# Altium schematic sheet 5-Latching Delay.SchDoc("5-Latching Delay")
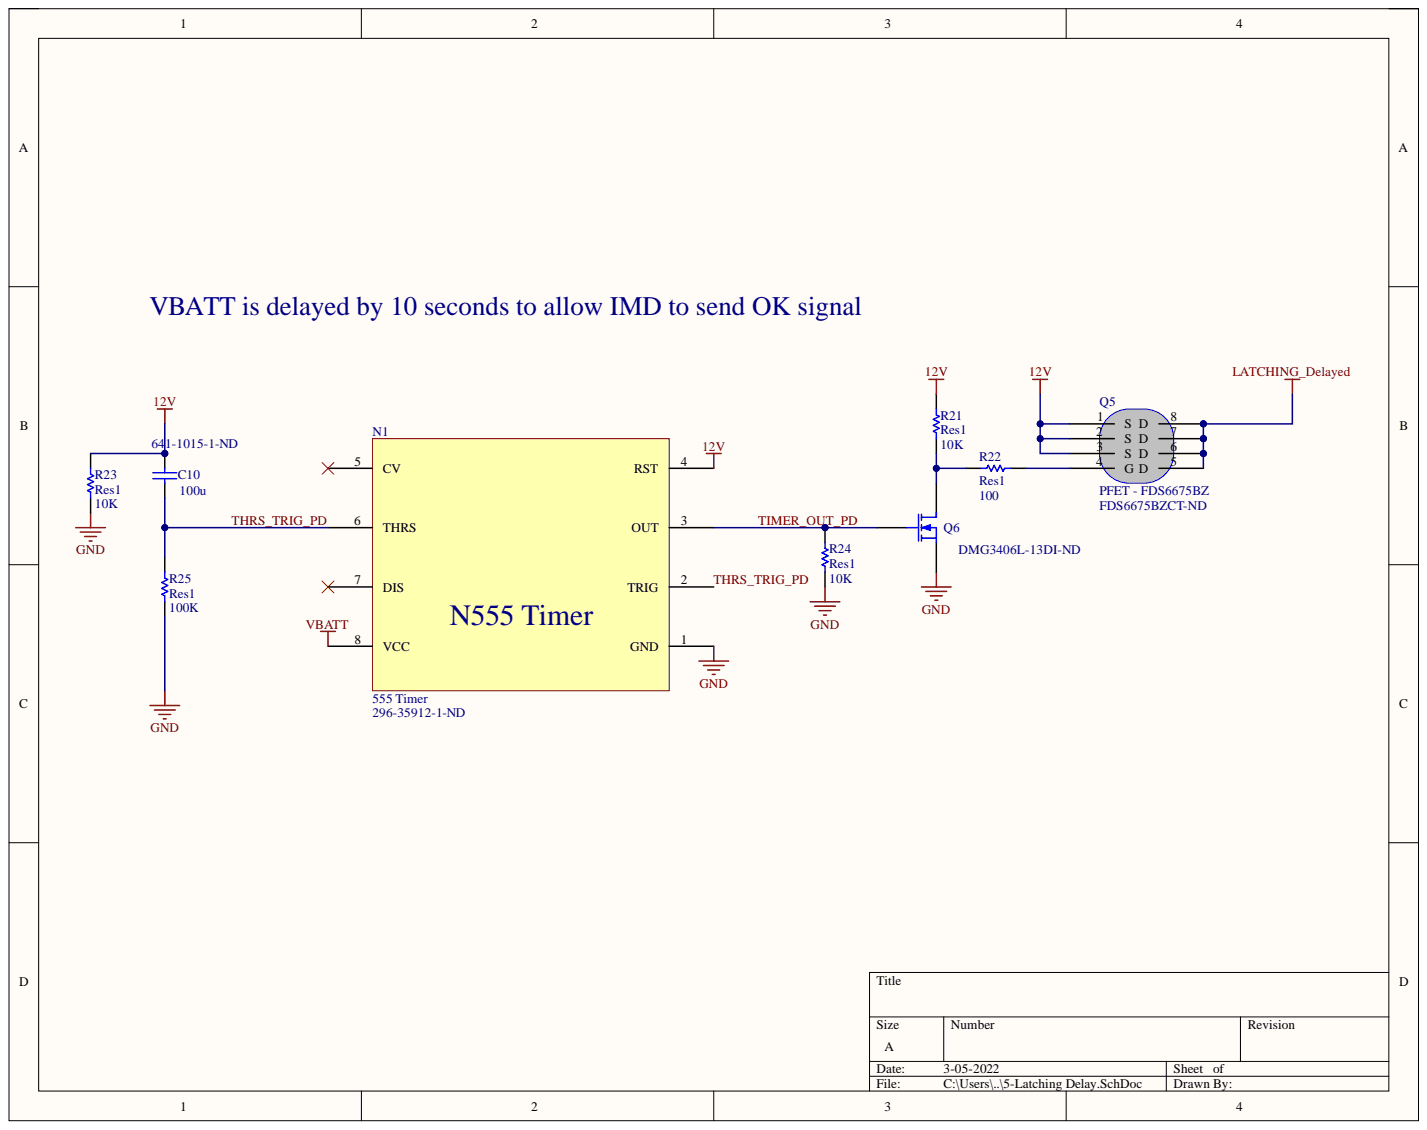
[diagram p0: 5-Latching Delay.SchDoc]

## Parts
C10  100u  Supplier Part Number 1: 641-1015-1-ND  [C1210]
N1  555 Timer  Supplier Part Number 1: 296-35912-1-ND  [SO8_M]
Q5  PFET - FDS6675BZ  Manufacturer Part Number: FDS6675BZ  Supplier Part Number 1: FDS6675BZCT-ND  [SOIC127P600X175-8N]
Q6  Supplier Part Number 1: DMG3406L-13DI-ND  [SOT-23_N]
R21  Res1  value 10K  [1608[0603]]
R22  Res1  value 100  [1608[0603]]
R23  Res1  value 10K  [1608[0603]]
R24  Res1  value 10K  [1608[0603]]
R25  Res1  value 100K  [1608[0603]]

## Nets
12V: C10.1 N1.4(RST) Q5.1(S) Q5.2(S) Q5.3(S) R21.2 R23.2
GND: N1.1(GND) Q6.2 R23.1 R24.1 R25.1
LATCHING_Delayed: Q5.5(D) Q5.6(D) Q5.7(D) Q5.8(D)
VBATT: N1.8(VCC)
THRS_TRIG_PD: C10.2 N1.2(TRIG) N1.6(THRS) R25.2
TIMER_OUT_PD: N1.3(OUT) Q6.1 R24.2
NetQ5_4: Q5.4(G) R22.2
NetQ6_3: Q6.3 R21.1 R22.1

Not connected: N1 5(CV) 7(DIS)

## Library data of the parts
C10 = Cap: CAP CER 100UF 6.3V X5R 1206; Manufacturer: Murata Electronics North America; Manufacturer Part Number: GRM31CR60J107ME39K; Capacitance: 100µF; Tolerance: ±20%; Applications: General Purpose; Category: Capacitors; Component Kind: Standard; ComponentLink1Description: http://www.murata.com/~/media/webrenewal/support/library/catalog/products/capacitor/mlcc/c02e.ashx; ComponentLink1URL: http://www.murata.com/~/media/webrenewal/support/library/catalog/products/capacitor/mlcc/c02e.ashx; Features: -; Height - Seated (Max): -; Lead Spacing: -; Lead Style: -; Library Name: Miscellaneous Devices.IntLib; Mounting Type: Surface Mount, MLCC; Operating Temperature: -55°C ~ 85°C; Package / Case: 1206 (3216 Metric); Packaging: Cut Tape (CT); Part Status: Active; Ratings: -; RoHS: RoHS Compliant; Signal Integrity: Cap; Simulation: CAP; Size / Dimension: 0.126" L x 0.063" W (3.20mm x 1.60mm); Supplier 1: Digi-Key; Temperature Coefficient: X5R; Thickness (Max): 0.071" (1.80mm); Voltage - Rated: 6.3V
N1 = 555 Timer: IC OSC SGL TIMER 100KHZ 8-SOIC; Manufacturer: Texas Instruments; Manufacturer Part Number: NE555DRG4; Current - Supply: 10mA; Voltage - Supply: 4.5 V ~ 16 V; Category: Integrated Circuits (ICs); Component Kind: Standard; ComponentLink1Description: http://www.ti.com/lit/ds/symlink/ne555.pdf; ComponentLink1URL: http://www.ti.com/lit/ds/symlink/ne555.pdf; Count: -; Frequency: 100kHz; Mounting Type: Surface Mount; Operating Temperature: 0°C ~ 70°C; Package / Case: 8-SOIC (0.154", 3.90mm Width); Packaging: Cut Tape (CT); Part Status: Active; Pricing 1: 1=0.71, 10=0.532, 100=0.3314, 500=0.22672, 1000=0.17441 (CAD); RoHS: RoHS Compliant; Stock 1: 6208; Supplier 1: Digi-Key; Supplier Device Package: 8-SOIC; Type: 555 Type, Timer/Oscillator (Single); Library Name: Latching  2017 - IMD.SCHLIB
Q5 = MOSFET: MOSFET P-CH 8-SOIC; Manufacturer: Fairchild/ON Semiconductor; Current - Continuous Drain (Id) @ 25°C: 11A (Ta); Drain to Source Voltage (Vdss): 30V; Drive Voltage (Max Rds On,  Min Rds On): 4.5V, 10V; FET Type: P-Channel; Gate Charge (Qg) (Max) @ Vgs: 62nC @ 10V; Input Capacitance (Ciss) (Max) @ Vds: 2470pF @ 15V; Power Dissipation (Max): 2.5W (Ta); Rds On (Max) @ Id, Vgs: 13 mOhm @ 11A, 10V; Vgs (Max): ±25V; Vgs(th) (Max) @ Id: 3V @ 250µA; Category: Discrete Semiconductor Products; Component Kind: Standard; ComponentLink1Description: https://www.fairchildsemi.com/datasheets/FD/FDS6675BZ.pdf; ComponentLink1URL: https://www.fairchildsemi.com/datasheets/FD/FDS6675BZ.pdf; FET Feature: -; Mounting Type: Surface Mount; Operating Temperature: -55°C ~ 150°C (TJ); Package / Case: 8-SOIC (0.154", 3.90mm Width); Packaging: Cut Tape (CT); Part Status: Active; Pricing 1: 1=1.29, 10=1.156, 100=0.9013, 500=0.74452, 1000=0.58778 (CAD); RoHS: RoHS Compliant; Stock 1: 7940; Supplier 1: Digi-Key; Supplier Device Package: 8-SO; Technology: MOSFET (Metal Oxide); Library Name: Latching_Reset_Library.SchLib
Q6 = MOSFET-N: N-Channel MOSFET; Manufacturer: Infineon Technologies; Manufacturer Part Number: IPD075N03L G; Current - Continuous Drain (Id) @ 25°C: 50A (Tc); Drain to Source Voltage (Vdss): 30V; FET Feature: Logic Level Gate; FET Type: MOSFET N-Channel, Metal Oxide; Gate Charge (Qg) @ Vgs: 18nC @ 10V; Input Capacitance (Ciss) @ Vds: 1900pF @ 15V; Power - Max: 47W; Rds On (Max) @ Id, Vgs: 7.5 mOhm @ 30A, 10V; Vgs(th) (Max) @ Id: 2.2V @ 250µA; Category: Discrete Semiconductor Products; Component Kind: Standard; ComponentLink1Description: http://www.infineon.com/dgdl/Infineon-IPD075N03LG-DS-v02_01-en.pdf?folderId=5546d4694909da4801490a07012f053b&fileId=db3a30431936bc4b011951d824f83aeb; ComponentLink1URL: http://www.infineon.com/dgdl/Infineon-IPD075N03LG-DS-v02_01-en.pdf?folderId=5546d4694909da4801490a07012f053b&fileId=db3a30431936bc4b011951d824f83aeb; ComponentLink2Description: http://www.semicon.toshiba.co.jp/info/docget.jsp?type=datasheet&lang=en&pid=SSM3K329R; ComponentLink2URL: http://www.semicon.toshiba.co.jp/info/docget.jsp?type=datasheet&lang=en&pid=SSM3K329R; LatestRevisionDate: 17-Jul-2002; LatestRevisionNote: Re-released for DXP Platform.; Library Name: Miscellaneous Devices.IntLib; Mounting Type: Surface Mount; Package / Case: TO-252-3, DPak (2 Leads + Tab), SC-63; PackageDescription: TO, Flat Index; 3 In-Line, Axial Leads; Body Dia. 4.6mm; Lead Dia. 0.5 mm (max); PackageReference: E3; PackageVersion: 1995/1996; Packaging: Cut Tape (CT); Published: 8-Jun-2000; RoHS: RoHS Compliant; Simulation: NMOS; Supplier 1: Digi-Key; Supplier Device Package: PG-TO252-3; Publisher: Altium Limited
R21 R23 R24 = Res1: RES SMD 10K OHM 5% 1/4W 0603; Manufacturer: Rohm Semiconductor; Manufacturer Part Number: ESR03EZPJ103; Power (Watts): 0.25W, 1/4W; Temperature Coefficient: ±200ppm/°C; Category: Resistors; Component Kind: Standard; ComponentLink1Description: http://rohmfs.rohm.com/en/products/databook/datasheet/passive/resistor/chip_resistor/esr.pdf; ComponentLink1URL: http://rohmfs.rohm.com/en/products/databook/datasheet/passive/resistor/chip_resistor/esr.pdf; Composition: Thick Film; Failure Rate: -; Features: Automotive AEC-Q200, Pulse Withstanding; Height - Seated (Max): 0.022" (0.55mm); LatestRevisionDate: 17-Jul-2002; LatestRevisionNote: Re-released for DXP Platform.; Library Name: Miscellaneous Devices.IntLib; Operating Temperature: -55°C ~ 155°C; Package / Case: 0603 (1608 Metric); PackageDescription: Axial Device, Thru-Hole; 2 Leads; 0.3 in Pin Spacing; PackageReference: AXIAL-0.3; Packaging: Cut Tape (CT); Part Status: Active; Pricing 1: 1=0.15, 10=0.119, 25=0.0824, 50=0.0642, 100=0.0458, 250=0.03568, 500=0.02836, 1000=0.02012 (CAD); Published: 8-Jun-2000; Resistance (Ohms): 10k; RoHS: RoHS Compliant; Signal Integrity: Res; Simulation: RESISTOR; Size / Dimension: 0.063" L x 0.031" W (1.60mm x 0.80mm); Stock 1: 0; Supplier 1: Digi-Key; Supplier Device Package: 0603; Supplier Part Number 1: RHM10KDCT-ND; Tolerance: ±5%; Publisher: Altium Limited
R22 = Res1: RES SMD 100 OHM 5% 1/4W 0603; Manufacturer: Rohm Semiconductor; Manufacturer Part Number: ESR03EZPJ101; Power (Watts): 0.25W, 1/4W; Temperature Coefficient: ±200ppm/°C; Category: Resistors; Component Kind: Standard; ComponentLink1Description: http://rohmfs.rohm.com/en/products/databook/datasheet/passive/resistor/chip_resistor/esr.pdf; ComponentLink1URL: http://rohmfs.rohm.com/en/products/databook/datasheet/passive/resistor/chip_resistor/esr.pdf; Composition: Thick Film; Failure Rate: -; Features: Automotive AEC-Q200, Pulse Withstanding; Height - Seated (Max): 0.022" (0.55mm); LatestRevisionDate: 17-Jul-2002; LatestRevisionNote: Re-released for DXP Platform.; Library Name: Miscellaneous Devices.IntLib; Operating Temperature: -55°C ~ 155°C; Package / Case: 0603 (1608 Metric); PackageDescription: Axial Device, Thru-Hole; 2 Leads; 0.3 in Pin Spacing; PackageReference: AXIAL-0.3; Packaging: Cut Tape (CT); Part Status: Active; Pricing 1: 1=0.15, 10=0.119, 25=0.0824, 50=0.0642, 100=0.0458, 250=0.03568, 500=0.02836, 1000=0.02012 (CAD); Published: 8-Jun-2000; Resistance (Ohms): 100; RoHS: RoHS Compliant; Signal Integrity: Res; Simulation: RESISTOR; Size / Dimension: 0.063" L x 0.031" W (1.60mm x 0.80mm); Stock 1: 57430; Supplier 1: Digi-Key; Supplier Device Package: 0603; Supplier Part Number 1: RHM100DCT-ND; Tolerance: ±5%; Publisher: Altium Limited
R25 = Res1: RES SMD 33K OHM 5% 1/4W 0603; Manufacturer: Rohm Semiconductor; Manufacturer Part Number: ESR03EZPJ333; Power (Watts): 0.25W, 1/4W; Temperature Coefficient: ±200ppm/°C; Category: Resistors; Component Kind: Standard; ComponentLink1Description: http://rohmfs.rohm.com/en/products/databook/datasheet/passive/resistor/chip_resistor/esr.pdf; ComponentLink1URL: http://rohmfs.rohm.com/en/products/databook/datasheet/passive/resistor/chip_resistor/esr.pdf; Composition: Thick Film; Failure Rate: -; Features: Automotive AEC-Q200, Pulse Withstanding; Height - Seated (Max): 0.022" (0.55mm); LatestRevisionDate: 17-Jul-2002; LatestRevisionNote: Re-released for DXP Platform.; Library Name: Miscellaneous Devices.IntLib; Operating Temperature: -55°C ~ 155°C; Package / Case: 0603 (1608 Metric); PackageDescription: Axial Device, Thru-Hole; 2 Leads; 0.3 in Pin Spacing; PackageReference: AXIAL-0.3; Packaging: Cut Tape (CT); Part Status: Active; Pricing 1: 1=0.15, 10=0.119, 25=0.0824, 50=0.0642, 100=0.0458, 250=0.03568, 500=0.02836, 1000=0.02012 (CAD); Published: 8-Jun-2000; Resistance (Ohms): 33k; RoHS: RoHS Compliant; Signal Integrity: Res; Simulation: RESISTOR; Size / Dimension: 0.063" L x 0.031" W (1.60mm x 0.80mm); Stock 1: 5269; Supplier 1: Digi-Key; Supplier Device Package: 0603; Supplier Part Number 1: RHM33KDCT-ND; Tolerance: ±5%; Publisher: Altium Limited
